# Altium schematic sheet 12V_to_5V_Power_Stage.SchDoc
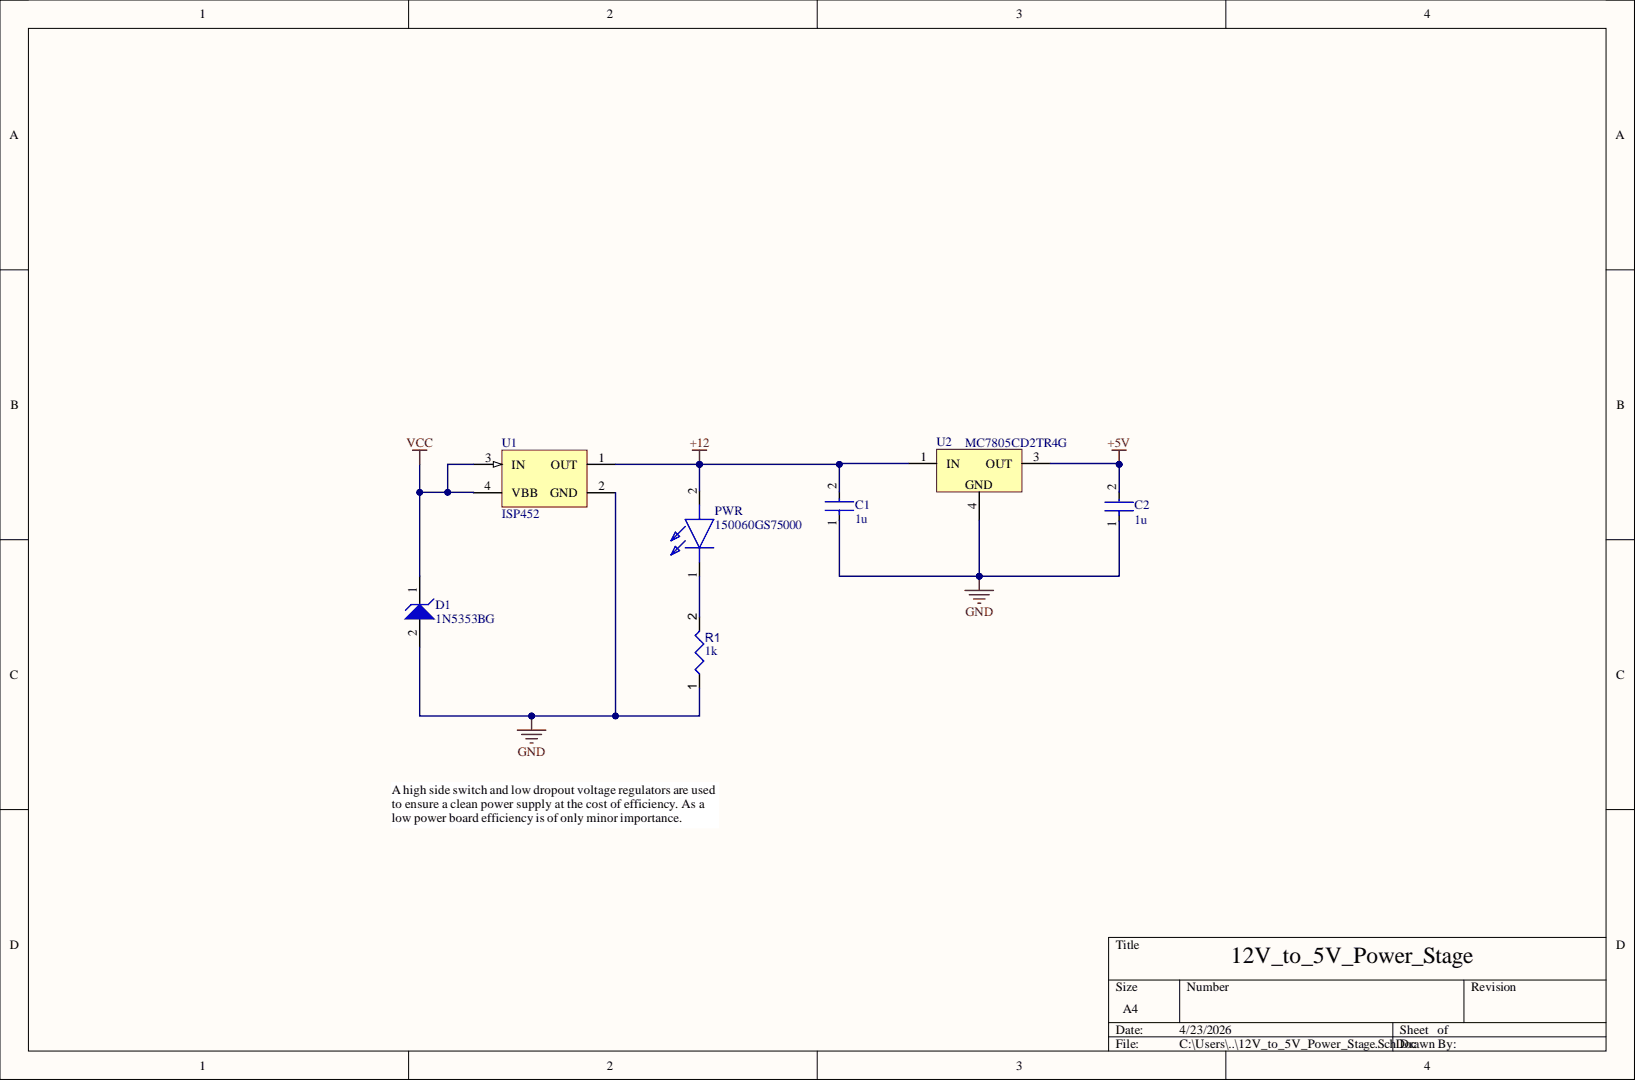
[diagram p0: 12V_to_5V_Power_Stage.SchDoc]

## Parts
C1  Capacitance: 1u  [1206-C]  (hidden comment: 1206 Capacitor)
C2  Capacitance: 1u  [1206-C]  (hidden comment: 1206 Capacitor)
D1  1N5353BG  [ONSC-SURMETIC40-2-017AA-01]
PWR  150060GS75000  [LEDC1608X80N]
R1  value 1k  [0603-R]  (hidden comment: 0603 Resistor)
U1  ISP452  [INF-SOT223_L]
U2  MC7805CD2TR4G  [ONSC-D2PAK-3-936-03_V]

## Nets
+5V: C2.2 U2.3
+12: C1.2 PWR.2 U1.1(OUT) U2.1
GND: C1.1 C2.1 D1.2 R1.1 U1.2(GND) U2.4
VCC: D1.1 U1.3(IN) U1.4(VBB)
NetPWR_1: PWR.1 R1.2

## Library data of the parts
C1 C2 = CMP-06035-003589-1: Manufacturer: Murata; Manufacturer Part Number: GRM31CR71E106KA12L; Dielectric: X7R; Material: Ceramic; Tolerance: 10%; Voltage: 25V; Case Code (Imperial): 1206; Case Code (Metric): 3216; Case/Package: 1206; Component Kind: Standard; Depth: 1.6mm; Description: 1206 Capacitor; Height: 1.6mm; Lead Free: Lead Free; Length: 3.2mm; Max Operating Temperature: 125°C; Min Operating Temperature: -55°C; Mount: Surface Mount; Number of Pins: 2; Package Quantity: 1; Packaging: Tape and Reel; Radiation Hardening: No; REACH SVHC: No SVHC; Termination: SMD/SMT; Thickness: 0.071inch; Voltage Rating: 25V; Voltage Rating (DC): 25V; Width: 1.6mm; Library Name: ePDM_V0.0.SCHLIB
D1 = CMP-1060-01290-1: 5 W Zener Voltage Regulator, 2-Pin Surmetic(TM) 40, Pb-Free, Bulk Box; Manufacturer: On Semiconductor; P Max (W): 5; VZ Typ (V): 16; Component Kind: Standard; ComponentLink1Description: Manufacturer URL; ComponentLink1URL: http://www.onsemi.com/; ComponentLink2Description: Datasheet; ComponentLink2URL: http://www.onsemi.cn/pub_link/Collateral/1N5333B-D.PDF; ComponentLink3Description: Package Specification; ComponentLink3URL: http://www.onsemi.cn/pub_link/Collateral/017AA.PDF; DatasheetVersion: Rev. 14; Manufacturer Part Number: 1N5353BG; Mounting Technology: Through Hole; PackageDescription: 2-Pin, Axial Lead, Diameter 3.49 mm; PackageReference: SURMETIC40-2-017AA-01; PackageVersion: Rev. O, 09/2005; Packing: Bulk Box; PartNumber: 1N5353BG; RoHS: Pb-Free; Library Name: ePDM_V0.0.SCHLIB
PWR = 150060GS75000: LED; Manufacturer_Name: Wurth Elektronik; Component Kind: Standard; Datasheet Link: https://componentsearchengine.com/Datasheets/2/150060GS75000.pdf; Height: 0.8mm; Manufacturer_Part_Number: 150060GS75000; Mouser Part Number: 710-150060GS75000; Mouser Price/Stock: https://www.mouser.co.uk/ProductDetail/Wurth-Elektronik/150060GS75000?qs=LlUlMxKIyB0UYkq5lDO8nA%3D%3D; Library Name: ePDM_V0.0.SCHLIB
R1 = CMP-009-00014-5_3: Manufacturer: Yageo; Manufacturer Part Number: RC0603FR-071KL; Power: 100mW; Tolerance: 1%; Voltage Rating: 50V; Case/Package: 0603; Component Kind: Standard; Component Type: Resistors; Description: 0603 Resistor; Max Operating Temperature: 155°C; Min Operating Temperature: -55°C; Mounting Technology: SM; Pins: 2; RoHS Compliant: True; Library Name: ePDM_V0.0.SCHLIB
U1 = CMP-1095-00141-1: Smart Power High-Side-Switch for Industrial Applications, 5 to 34 V Operating, -30 to 85 degC, SOT223, Reel, Green; Manufacturer: Infineon; Channels: 1; Green: TRUE; IL (nom)min - all channels active: 0.7Â A; IL (nom)min - one channel active: 0.8Â A; IL (SC): 1.5Â A; Operating Voltage Range (max): 34 V; Operating Voltage Range (min): 5 V; Ptot (max): 1.8Â W; RON (max) @ Tj max: 400 mOhm; RON @ 25 degC: 200 mOhm (max); toff (max): 150 us; ton (max): 100 us; Component Kind: Standard; ComponentLink1Description: Manufacturer URL; ComponentLink1URL: http://www.infineon.com/; ComponentLink2Description: Datasheet; ComponentLink2URL: http://www.infineon.com/dgdl/ISP452_20060301.pdf?folderId=db3a304412b407950112b408e8c90004&fileId=db3a304412b407950112b42957ef40c3; ComponentLink3Description: Package Specification; ComponentLink3URL: http://www.infineon.com/dgdl/SOT223-Package_Overview.pdf?folderId=db3a30431936bc4b0119530470b03c3d&fileId=db3a30431936bc4b0119531d40b93c5c; IL (SCp): 1.5Â A; Load Current (typ): 0.7Â A; Manufacturer Part Number: ISP452; Mounting Technology: Surface Mount; PackageDescription: 4-Pin Small Outline Transistor Package, 6.5 x 3.5 mm Body, 2.3 mm Pitch; PackageReference: SOT223; PackageVersion: Apr-08; Packing: Reel; PartNumber: ISP452; Library Name: ePDM_V0.0.SCHLIB
U2 = CMP-1679-00001-4: Positive Voltage Regulator, 1 A, 5 V Output Voltage, 0 to 125 degC, 3-Pin D2PAK (936-03), Pb-Free, Tape and Reel; Manufacturer: ON Semiconductor; Component Kind: Standard; ComponentLink1Description: Manufacturer URL; ComponentLink1URL: http://www.onsemi.com/; ComponentLink2Description: Datasheet; ComponentLink2URL: http://www.onsemi.com/pub_link/Collateral/MC7800-D.PDF; ComponentLink3Description: Package Specification; ComponentLink3URL: http://www.onsemi.com/pub_link/Collateral/936-03.PDF; DatasheetVersion: Rev. 27, 11/2014; Height: 30.02 mm; Length: 10.24 mm; Manufacturer Part Number: MC7805CD2TR4G; Max Operating Temperature: 125 degC; Min Operating Temperature: 0 degC; Mount: Surface Mount; Mounting Technology: Surface Mount; Package Quantity: 800; PackageDescription: 3-Pin Transistor Outline, Body 10.02 x 9.1945 mm, Pitch 2.54 mm; PackageReference: D2PAK-3-936-03; PackageVersion: Rev. E, 09/2015; Packaging: Tape and Reel; Pins: 3; REACH SVHC: No SVHC; RoHSCompliant: true; Library Name: ePDM_V0.0.SCHLIB
